# Altium schematic sheet Sheet2.SchDoc
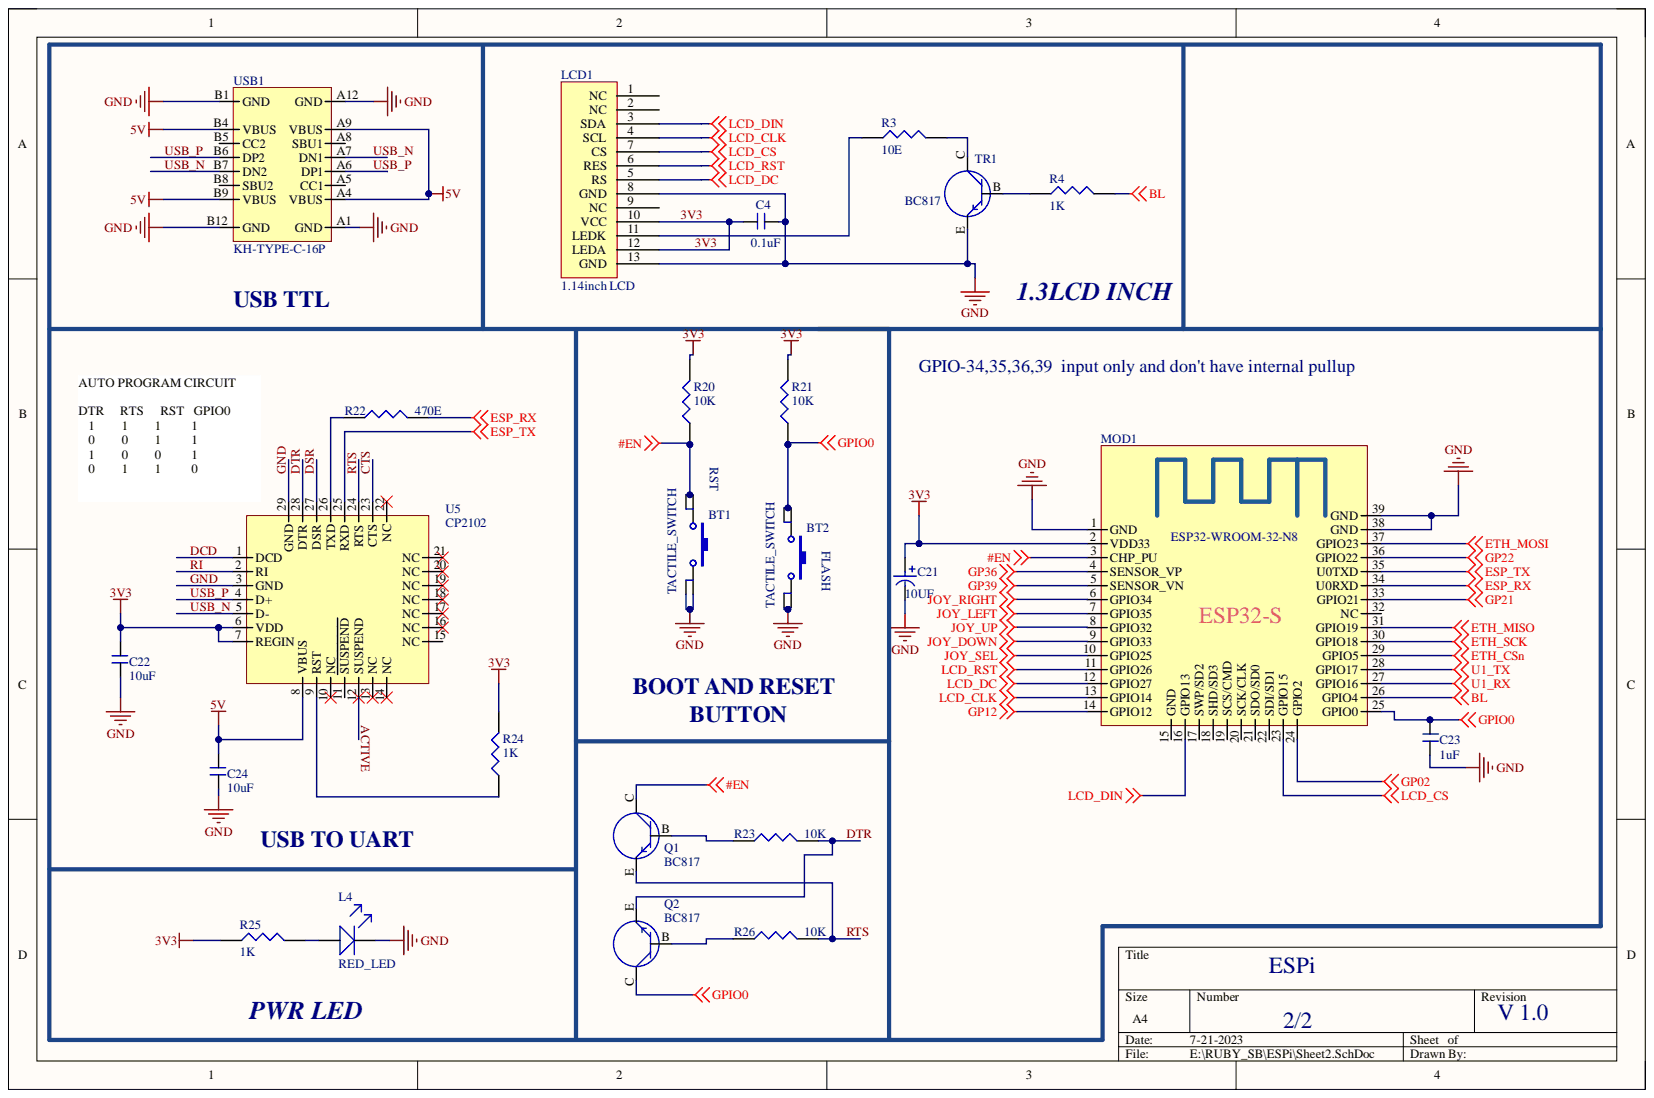
[diagram p0: Sheet2.SchDoc]

## Parts
BT1  TACTILE_SWITCH  [TACTILE]
BT2  TACTILE_SWITCH  [TACTILE]
C4  0.1uF  [C_0603]
C21  10UF  [tantlum caseA]
C22  10uF  [C_0603]
C23  1uF  [C_0603]
C24  10uF  [C_0603]
L4  RED_LED  [LED_0603]
LCD1  1.14inch LCD  [1.14 inch]
MOD1  ESP32-WROOM-32-N8  [ESP32-S WROOM-32]
Q1  BC817  [SOT23]
Q2  BC817  [SOT23]
R3  10E  [R_0603]
R4  1K  [R_0603]
R20  10K  [R_0603]
R21  10K  [R_0603]
R22  470E  [R_0603]
R23  10K  [R_0603]
R24  1K  [R_0603]
R25  1K  [R_0603]
R26  10K  [R_0603]
TR1  BC817  [SOT23]
U5  CP2102  [CP2102]
USB1  KH-TYPE-C-16P  [USBC-31-M-12]

## Nets
5V: C24.1 U5.8(VBUS) USB1.A4(VBUS) USB1.A9(VBUS) USB1.B4(VBUS) USB1.B9(VBUS)
#EN: BT1.1 BT1.2 MOD1.3(CHP_PU) Q1.C R20.1
BL: MOD1.26(GPIO4) R4.1
ESP_RX: MOD1.34(U0RXD) R22.2
ESP_TX: MOD1.35(U0TXD) U5.25(RXD)
ETH_CSn: MOD1.29(GPIO5)
ETH_MISO: MOD1.31(GPIO19)
ETH_MOSI: MOD1.37(GPIO23)
ETH_SCK: MOD1.30(GPIO18)
GP02: MOD1.24(GPIO2)
GP12: MOD1.14(GPIO12)
GP21: MOD1.33(GPIO21)
GP22: MOD1.36(GPIO22)
GP36: MOD1.4(SENSOR_VP)
GP39: MOD1.5(SENSOR_VN)
GPIO0: BT2.1 BT2.2 C23.2 MOD1.25(GPIO0) Q2.C R21.1
JOY_DOWN: MOD1.9(GPIO33)
JOY_LEFT: MOD1.7(GPIO35)
JOY_RIGHT: MOD1.6(GPIO34)
JOY_SEL: MOD1.10(GPIO25)
JOY_UP: MOD1.8(GPIO32)
LCD_CLK: LCD1.4(SCL) MOD1.13(GPIO14)
LCD_CS: LCD1.7(CS) MOD1.23(GPIO15)
LCD_DC: LCD1.5(RS) MOD1.12(GPIO27)
LCD_DIN: LCD1.3(SDA) MOD1.16(GPIO13)
LCD_RST: LCD1.6(RES) MOD1.11(GPIO26)
U1_RX: MOD1.27(GPIO16)
U1_TX: MOD1.28(GPIO17)
3V3: C4.2 C21.1 C22.1 LCD1.10(VCC) LCD1.12(LEDA) MOD1.2(VDD33) R20.2 R21.2 R24.2 R25.1 U5.6(VDD) U5.7(REGIN)
ACTIVE: U5.12(SUSPEND)
CTS: U5.23(CTS)
DCD: U5.1(DCD)
DSR: U5.27(DSR)
DTR: Q2.E R23.2 U5.28(DTR)
GND: BT1.3 BT1.4 BT2.3 BT2.4 C4.1 C21.2 C22.2 C23.1 C24.2 L4.K LCD1.8(GND) LCD1.13(GND) MOD1.1(GND) MOD1.38(GND) MOD1.39(GND) TR1.E U5.3(GND) U5.29(GND) USB1.A1(GND) USB1.A12(GND) USB1.B1(GND) USB1.B12(GND)
RI: U5.2(RI)
RTS: Q1.E R26.2 U5.24(RTS)
USB_N: U5.5(D-) USB1.A7(DN1) USB1.B7(DN2)
USB_P: U5.4(D+) USB1.A6(DP1) USB1.B6(DP2)
NetL4_A: L4.A R25.2
NetLCD1_11: LCD1.11(LEDK) R3.1
NetQ1_B: Q1.B R23.1
NetQ2_B: Q2.B R26.1
NetR3_2: R3.2 TR1.C
NetR4_2: R4.2 TR1.B
NetR22_1: R22.1 U5.26(TXD)
NetR24_1: R24.1 U5.9(RST)

Not connected: LCD1 1(NC) 2(NC) 9(NC); MOD1 15(GND) 17(SWP/SD2) 18(SHD/SD3) 19(SCS/CMD) 20(SCK/CLK) 21(SDO/SD0) 22(SDI/SD1) 32(NC); U5 10(NC) 11(SUSPEND) 13(NC) 14(NC) 15(NC) 16(NC) 17(NC) 18(NC) 19(NC) 20(NC) 21(NC) 22(NC); USB1 A5(CC1) A8(SBU1) B5(CC2) B8(SBU2)

## Library data of the parts
C4 C22-C24 = CAP_NONPOLAR: Component Kind: Standard; Library Name: dxp_pasl_lib.IntLib
C21 = CAP_ELE: Component Kind: Standard; Library Name: MIX.SchLib
L4 = LED: Component Kind: Standard; Library Name: dxp_pasl_lib.IntLib
LCD1 = LCD 1.14INCH: 1.14inch LCD Display 65K RGB Colors, 240×135 Pixels, SPI Interface; Component Kind: Standard; Library Name: dxp_pasl_lib.IntLib
MOD1 = ESP32-S: Ai-Thinker ESP32-S SMD Module WiFi Modules ROHS  #C277944; Component Kind: Standard; ComponentLink1Description: New link; ComponentLink1URL: https://lcsc.com/product-detail/WiFi-Modules_Ai-Thinker-ESP32-S_C277944.html; Library Name: dxp_pasl_lib.IntLib
Q1 Q2 TR1 = TRANSISTER_NPN: Component Kind: Standard; Library Name: dxp_pasl_lib.IntLib
R3 R4 R20-R26 = RES: Component Kind: Standard; Library Name: dxp_pasl_lib.IntLib
U5 = CP2102_1: QFN, 28-Leads, Body 5.0x5.0mm (max), Pitch 0.50mm, IPC Medium Density; Component Kind: Standard; Library Name: dxp_pasl_lib.IntLib
USB1 = USB TYPE-C KH-TYPE-C-16P: 3A 1 Surface Mount 16 Female -40?~+85? Type-C SMD USB Connectors ROHS #  C709357; Component Kind: Standard; ComponentLink2Description: New link; ComponentLink2URL: https://lcsc.com/product-detail/USB-Connectors_Shenzhen-Kinghelm-Elec-KH-TYPE-C-16P_C709357.html; Supplier 1: LCSC; Supplier Part Number 1: C165948; Library Name: dxp_pasl_lib.IntLib
BT1 BT2 = TACTILE_SWITCH: Component Kind: Standard; Library Name: dxp_pasl_lib.IntLib
